# Altium schematic sheet 04_BANK_3V3.SchDoc
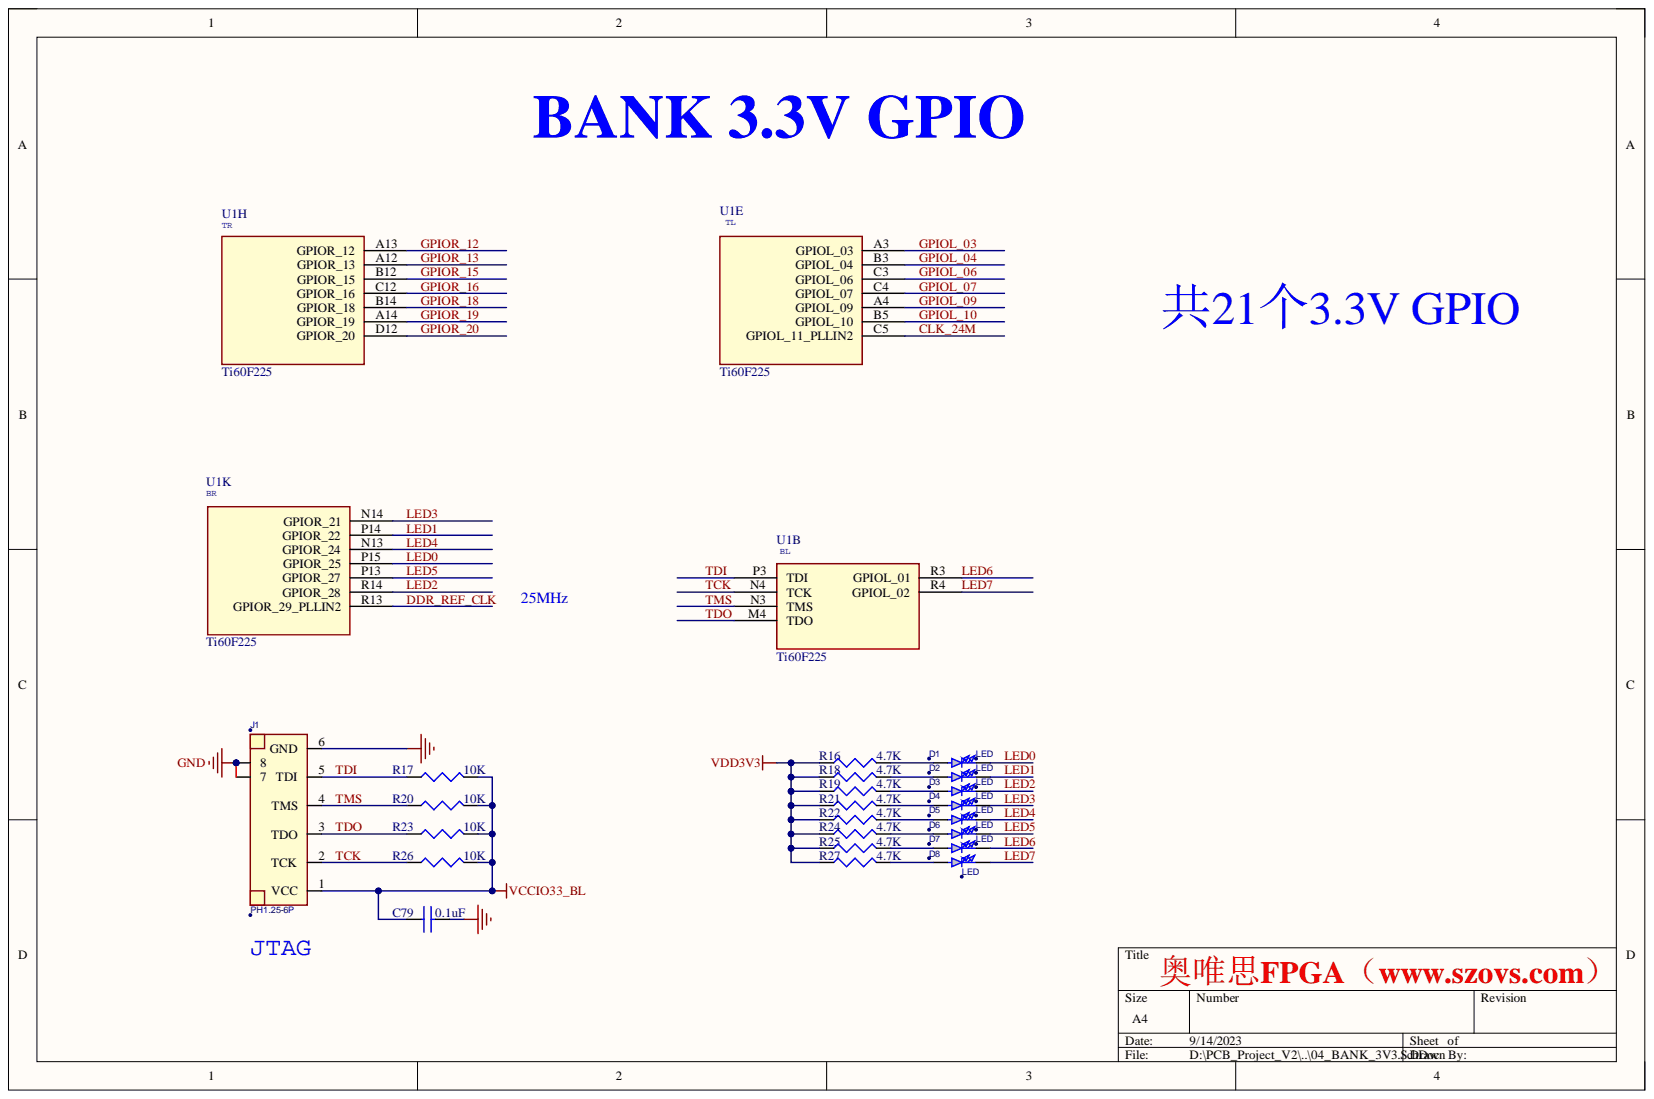
[diagram p0: 04_BANK_3V3.SchDoc]

## Parts
C79  0.1uF  [R0402]
D1  LED  [D0603]
D2  LED  [D0603]
D3  LED  [D0603]
D4  LED  [D0603]
D5  LED  [D0603]
D6  LED  [D0603]
D7  LED  [D0603]
D8  LED  [D0603]
J1  PH1.25-6P  [PH1.25-6]
R16  4.7K  [R0402]
R17  10K  [R0402]
R18  4.7K  [R0402]
R19  4.7K  [R0402]
R20  10K  [R0402]
R21  4.7K  [R0402]
R22  4.7K  [R0402]
R23  10K  [R0402]
R24  4.7K  [R0402]
R25  4.7K  [R0402]
R26  10K  [R0402]
R27  4.7K  [R0402]
U1  Ti60F225  [ti60F225]  (parts B,E,H,K)

## Nets
GND: C79.2 J1.6(GND) J1.7 J1.8
VCCIO33_BL: C79.1 J1.1(VCC) R17.2 R20.2 R23.2 R26.2
VDD3V3: R16.1 R18.1 R19.1 R21.1 R22.1 R24.1 R25.1 R27.1
CLK_24M: U1.C5(GPIOL_11_PLLIN2)
DDR_REF_CLK: U1.R13(GPIOR_29_PLLIN2)
GPIOL_03: U1.A3(GPIOL_03)
GPIOL_04: U1.B3(GPIOL_04)
GPIOL_06: U1.C3(GPIOL_06)
GPIOL_07: U1.C4(GPIOL_07)
GPIOL_09: U1.A4(GPIOL_09)
GPIOL_10: U1.B5(GPIOL_10)
GPIOR_12: U1.A13(GPIOR_12)
GPIOR_13: U1.A12(GPIOR_13)
GPIOR_15: U1.B12(GPIOR_15)
GPIOR_16: U1.C12(GPIOR_16)
GPIOR_18: U1.B14(GPIOR_18)
GPIOR_19: U1.A14(GPIOR_19)
GPIOR_20: U1.D12(GPIOR_20)
LED0: D1.2 U1.P15(GPIOR_25)
LED1: D2.2 U1.P14(GPIOR_22)
LED2: D3.2 U1.R14(GPIOR_28)
LED3: D4.2 U1.N14(GPIOR_21)
LED4: D5.2 U1.N13(GPIOR_24)
LED5: D6.2 U1.P13(GPIOR_27)
LED6: D7.2 U1.R3(GPIOL_01)
LED7: D8.2 U1.R4(GPIOL_02)
TCK: J1.2(TCK) R26.1 U1.N4(TCK)
TDI: J1.5(TDI) R17.1 U1.P3(TDI)
TDO: J1.3(TDO) R23.1 U1.M4(TDO)
TMS: J1.4(TMS) R20.1 U1.N3(TMS)
NetD1_1: D1.1 R16.2
NetD2_1: D2.1 R18.2
NetD3_1: D3.1 R19.2
NetD4_1: D4.1 R21.2
NetD5_1: D5.1 R22.2
NetD6_1: D6.1 R24.2
NetD7_1: D7.1 R25.2
NetD8_1: D8.1 R27.2

## Library data of the parts
C79 = C0402: Component Kind: Standard; Library Name: 162_Library.SchLib
D1-D8 = 2led: Component Kind: Standard
J1 = 6p-jtag: Component Kind: Standard; Library Name: Ti60_F225_Core.SCHLIB
R16-R27 = R: Component Kind: Standard; Library Name: 162_Library.SchLib
U1 = Ti60F225: SPLIT_INST: TRUE; SWAP_INFO: (S1+S2+S3+S4+S5+S6+S7+S8+S9+S10+S11+S12+S13); Component Kind: Standard; Library Name: 162_Library.SchLib
